# Altium schematic sheet lt_sys.SchDoc(lt_sys)
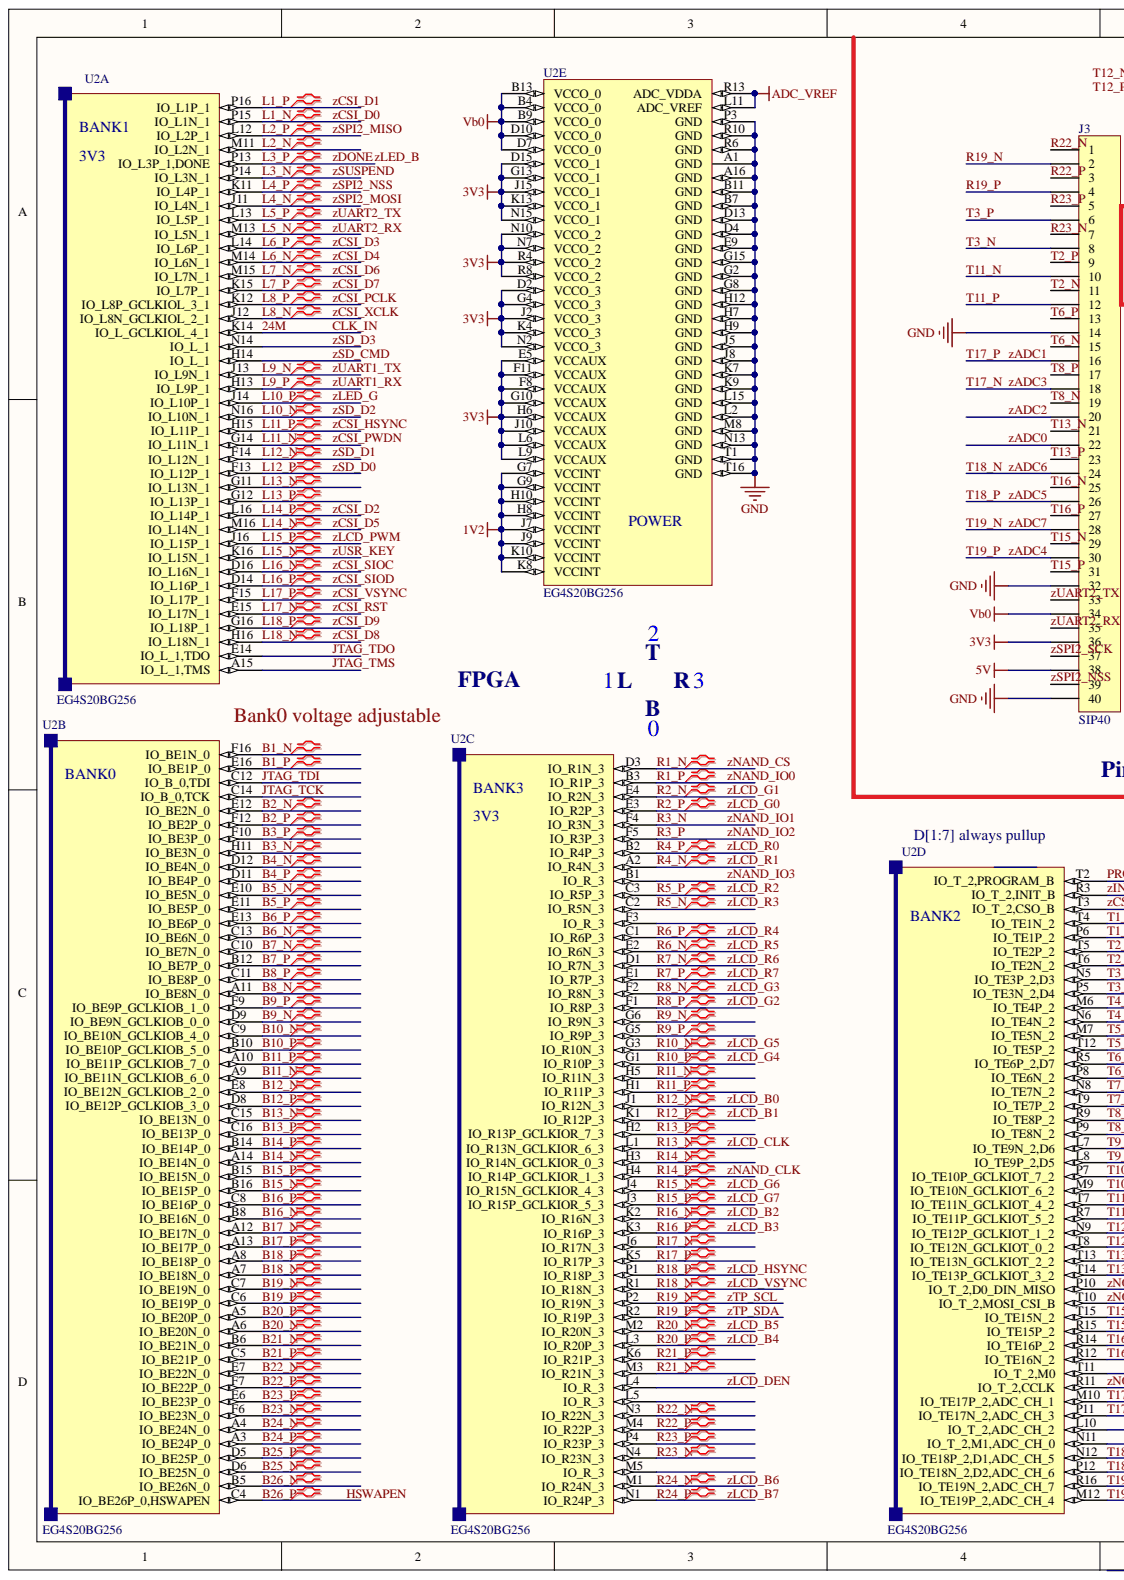
[diagram p0: lt_sys.SchDoc, left]
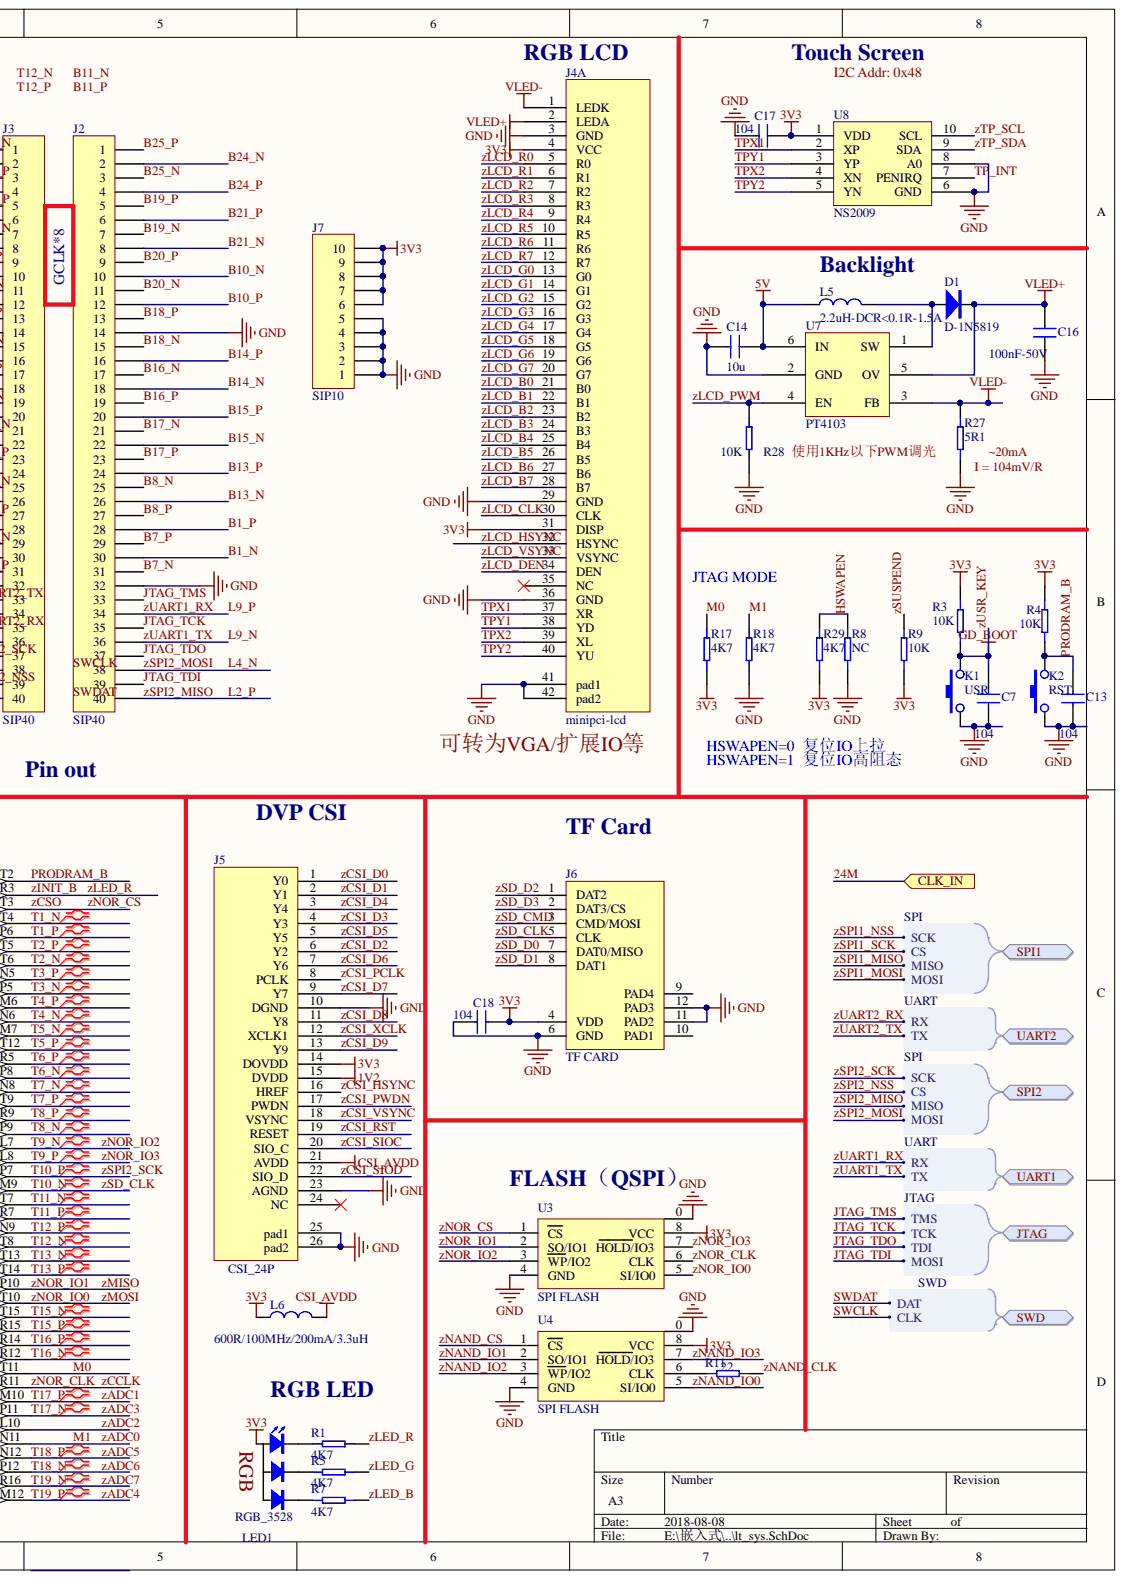
[diagram p1: lt_sys.SchDoc, right]

## Parts
C7  104  [0402C]
C13  104  [0402C]
C14  10u  [0603C]
C16  100nF-50V  [0402C]
C17  104  [0402C]
C18  104  [0402C]
D1  D-1N5819  [SOD-323]
J2  SIP40  [SIP40_20_1.27]
J3  SIP40  [SIP40_20_1.27]
J4  minipci-lcd  [FPC-40P-0.5]  (part A)
J5  CSI_24P  [FPC-24P-0.5]
J6  TF CARD  [TF_self-ejectC]
J7  SIP10  [SIP10_2.54_smt]
K1  USR  [key4_3x4]
K2  RST  [key4_3x4]
L5  2.2uH-DCR<0.1R-1.5A  [0806L]
L6  600R/100MHz/200mA/3.3uH  [0603R]
LED1  RGB_3528  [LED_RGB3528]
R1  4K7  [0402R]
R3  10K  [0402R]
R4  10K  [0402R]
R5  4K7  [0402R]
R7  4K7  [0402R]
R8  NC  [0402R]
R9  10K  [0402R]
R11  22  [0402R]  (value not drawn)
R17  4K7  [0402R]
R18  4K7  [0402R]
R27  5R1  [0402R]
R28  10K  [0402R]
R29  4K7  [0402R]
U2  EG4S20BG256  [FG256]  (parts A,B,C,D,E)
U3  SPI FLASH  [WSON8]
U4  SPI FLASH  [WSON8]
U7  PT4103  [sot23_6]
U8  NS2009  [MSOP10]

## Ports
CLK_IN, JTAG, JTAG.MOSI, JTAG.TCK, JTAG.TDI, JTAG.TMS, SPI1, SPI1.CS, SPI1.MISO, SPI1.MOSI, SPI1.SCK, SPI2, SPI2.CS, SPI2.MISO, SPI2.MOSI, SPI2.SCK, SWD, SWD.CLK, SWD.DAT, UART1, UART1.RX, UART1.TX, UART2, UART2.RX, UART2.TX

## Nets
1V2: J5.15(DVDD) U2.G7(VCCINT) U2.G9(VCCINT) U2.H8(VCCINT) U2.H10(VCCINT) U2.J7(VCCINT) U2.J9(VCCINT) U2.K8(VCCINT) U2.K10(VCCINT)
3V3: C17.1 C18.1 J3.36 J4.4(VCC) J4.31(DISP) J5.14(DOVDD) J6.4(VDD) J7.6 J7.7 J7.8 J7.9 J7.10 L6.2 LED1.2 R3.2 R4.2 R9.2 R17.1 R29.2 U2.D2(VCCO_3) U2.D15(VCCO_1) U2.E5(VCCAUX) U2.F8(VCCAUX) U2.F11(VCCAUX) U2.G4(VCCO_3) U2.G10(VCCAUX) U2.G13(VCCO_1) U2.H6(VCCAUX) U2.J2(VCCO_3) U2.J10(VCCAUX) U2.J15(VCCO_1) U2.K4(VCCO_3) U2.K13(VCCO_1) U2.L6(VCCAUX) U2.L9(VCCAUX) U2.N2(VCCO_3) U2.N7(VCCO_2) U2.N10(VCCO_2) U2.N15(VCCO_1) U2.R4(VCCO_2) U2.R8(VCCO_2) U3.8(VCC) U4.8(VCC) U8.1(VDD)
5V: C14.1 J3.38 L5.2 U7.6(IN)
ADC_VREF: U2.L11(ADC_VREF) U2.R13(ADC_VDDA)
CSI_AVDD: J5.21(AVDD) L6.1
GND: C7.2 C13.2 C14.2 C16.1 C17.2 C18.2 J2.14 J2.32 J3.14 J3.32 J3.40 J4.3(GND) J4.29(GND) J4.36(GND) J4.41(pad1) J4.42(pad2) J5.10(DGND) J5.23(AGND) J5.25(pad1) J5.26(pad2) J6.6(GND) J6.11(PAD2) J6.12(PAD3) J7.1 J7.2 J7.3 J7.4 J7.5 K1.1 K2.1 R8.2 R18.1 R27.1 R28.2 U2.A1(GND) U2.A16(GND) U2.B7(GND) U2.B11(GND) U2.D4(GND) U2.D13(GND) U2.E9(GND) U2.G2(GND) U2.G8(GND) U2.G15(GND) U2.H7(GND) U2.H9(GND) U2.H12(GND) U2.J5(GND) U2.J8(GND) U2.K7(GND) U2.K9(GND) U2.L2(GND) U2.L15(GND) U2.M8(GND) U2.N13(GND) U2.P3(GND) U2.R6(GND) U2.R10(GND) U2.T1(GND) U2.T16(GND) U3.0 U3.4(GND) U4.0 U4.4(GND) U7.2(GND) U8.6(GND) U8.8(A0)
VB0: J3.34 U2.B4(VCCO_0) U2.B9(VCCO_0) U2.B13(VCCO_0) U2.D7(VCCO_0) U2.D10(VCCO_0)
VLED+: C16.2 D1.K J4.2(LEDA) U7.5(OV)
VLED-: J4.1(LEDK) R27.2 U7.3(FB)
24M: U2.K14(IO_L_GCLKIOL_4_1) ; port CLK_IN
B1_N: J2.30 U2.F16(IO_BE1N_0)
B1_P: J2.28 U2.E16(IO_BE1P_0)
B2_N: U2.E12(IO_BE2N_0)
B2_P: U2.F12(IO_BE2P_0)
B3_N: U2.H11(IO_BE3N_0)
B3_P: U2.F10(IO_BE3P_0)
B4_N: U2.D12(IO_BE4N_0)
B4_P: U2.D11(IO_BE4P_0)
B5_N: U2.E10(IO_BE5N_0)
B5_P: U2.E11(IO_BE5P_0)
B6_N: U2.C13(IO_BE6N_0)
B6_P: U2.E13(IO_BE6P_0)
B7_N: J2.31 U2.C10(IO_BE7N_0)
B7_P: J2.29 U2.B12(IO_BE7P_0)
B8_N: J2.25 U2.A11(IO_BE8N_0)
B8_P: J2.27 U2.C11(IO_BE8P_0)
B9_N: U2.D9(IO_BE9N_GCLKIOB_0_0)
B9_P: U2.F9(IO_BE9P_GCLKIOB_1_0)
B10_N: J2.10 U2.C9(IO_BE10N_GCLKIOB_4_0)
B10_P: J2.12 U2.B10(IO_BE10P_GCLKIOB_5_0)
B11_N: U2.A9(IO_BE11N_GCLKIOB_6_0)
B11_P: U2.A10(IO_BE11P_GCLKIOB_7_0)
B12_N: U2.E8(IO_BE12N_GCLKIOB_2_0)
B12_P: U2.D8(IO_BE12P_GCLKIOB_3_0)
B13_N: J2.26 U2.C15(IO_BE13N_0)
B13_P: J2.24 U2.C16(IO_BE13P_0)
B14_N: J2.18 U2.A14(IO_BE14N_0)
B14_P: J2.16 U2.B14(IO_BE14P_0)
B15_N: J2.22 U2.B16(IO_BE15P_0)
B15_P: J2.20 U2.B15(IO_BE15N_0)
B16_N: J2.17 U2.B8(IO_BE16N_0)
B16_P: J2.19 U2.C8(IO_BE16P_0)
B17_N: J2.21 U2.A12(IO_BE17N_0)
B17_P: J2.23 U2.A13(IO_BE17P_0)
B18_N: J2.15 U2.A7(IO_BE18N_0)
B18_P: J2.13 U2.A8(IO_BE18P_0)
B19_N: J2.7 U2.C7(IO_BE19N_0)
B19_P: J2.5 U2.C6(IO_BE19P_0)
B20_N: J2.11 U2.A6(IO_BE20N_0)
B20_P: J2.9 U2.A5(IO_BE20P_0)
B21_N: J2.8 U2.B6(IO_BE21N_0)
B21_P: J2.6 U2.C5(IO_BE21P_0)
B22_N: U2.E7(IO_BE22N_0)
B22_P: U2.F7(IO_BE22P_0)
B23_N: U2.F6(IO_BE23N_0)
B23_P: U2.E6(IO_BE23P_0)
B24_N: J2.2 U2.A4(IO_BE24N_0)
B24_P: J2.4 U2.A3(IO_BE24P_0)
B25_N: J2.3 U2.D6(IO_BE25N_0)
B25_P: J2.1 U2.D5(IO_BE25P_0)
B26_N: U2.B5(IO_BE26N_0)
B26_P: R8.1 R29.1 U2.C4(IO_BE26P_0,HSWAPEN)
JTAG_TCK: J2.35 U2.C14(IO_B_0,TCK) ; port JTAG
JTAG_TDI: J2.39 U2.C12(IO_B_0,TDI) ; port JTAG
JTAG_TDO: J2.37 U2.E14(IO_L_1,TDO) ; port JTAG
JTAG_TMS: J2.33 U2.A15(IO_L_1,TMS) ; port JTAG
L1_N: J5.1(Y0) U2.P15(IO_L1N_1)
L1_P: J5.2(Y1) U2.P16(IO_L1P_1)
L2_N: U2.M11(IO_L2N_1)
L2_P: J2.40 U2.L12(IO_L2P_1) ; port SPI2
L3_N: R9.1 U2.P14(IO_L3N_1)
L3_P: R7.1 U2.P13(IO_L3P_1,DONE)
L4_N: J2.38 U2.J11(IO_L4N_1) ; port SPI2
L4_P: J3.39 U2.K11(IO_L4P_1) ; port SPI2
L5_N: J3.35 U2.M13(IO_L5N_1) ; port UART2
L5_P: J3.33 U2.L13(IO_L5P_1) ; port UART2
L6_N: J5.3(Y4) U2.M14(IO_L6N_1)
L6_P: J5.4(Y3) U2.L14(IO_L6P_1)
L7_N: J5.7(Y6) U2.M15(IO_L7N_1)
L7_P: J5.9(Y7) U2.K15(IO_L7P_1)
L8_N: J5.12(XCLK1) U2.J12(IO_L8N_GCLKIOL_2_1)
L8_P: J5.8(PCLK) U2.K12(IO_L8P_GCLKIOL_3_1)
L9_N: J2.36 U2.J13(IO_L9N_1) ; port UART1
L9_P: J2.34 U2.H13(IO_L9P_1) ; port UART1
L10_N: J6.1(DAT2) U2.N16(IO_L10N_1)
L10_P: R5.1 U2.J14(IO_L10P_1)
L11_N: J5.17(PWDN) U2.G14(IO_L11N_1)
L11_P: J5.16(HREF) U2.H15(IO_L11P_1)
L12_N: J6.8(DAT1) U2.F14(IO_L12N_1)
L12_P: J6.7(DAT0/MISO) U2.F13(IO_L12P_1)
L13_N: U2.G11(IO_L13N_1)
L13_P: U2.G12(IO_L13P_1)
L14_N: J5.5(Y5) U2.M16(IO_L14N_1)
L14_P: J5.6(Y2) U2.L16(IO_L14P_1)
L15_N: C7.1 K1.2 R3.1 U2.K16(IO_L15N_1)
L15_P: R28.1 U2.J16(IO_L15P_1) U7.4(EN)
L16_N: J5.20(SIO_C) U2.D16(IO_L16N_1)
L16_P: J5.22(SIO_D) U2.D14(IO_L16P_1)
L17_N: J5.19(RESET) U2.E15(IO_L17N_1)
L17_P: J5.18(VSYNC) U2.F15(IO_L17P_1)
L18_N: J5.11(Y8) U2.H16(IO_L18N_1)
L18_P: J5.13(Y9) U2.G16(IO_L18P_1)
M0: R17.2 U2.T11(IO_T_2,M0)
M1: J3.22 R18.2 U2.N11(IO_T_2,M1,ADC_CH_0)
PRODRAM_B: C13.1 K2.2 R4.1 U2.T2(IO_T_2,PROGRAM_B)
R1_N: U2.D3(IO_R1N_3) U4.1(CS)
R1_P: U2.B3(IO_R1P_3) U4.5(SI/IO0)
R2_N: J4.14(G1) U2.E4(IO_R2N_3)
R2_P: J4.13(G0) U2.E3(IO_R2P_3)
R3_N: U2.F4(IO_R3N_3) U4.2(SO/IO1)
R3_P: U2.F5(IO_R3P_3) U4.3(WP/IO2)
R4_N: J4.6(R1) U2.A2(IO_R4N_3)
R4_P: J4.5(R0) U2.B2(IO_R4P_3)
R5_N: J4.8(R3) U2.C2(IO_R5N_3)
R5_P: J4.7(R2) U2.C3(IO_R5P_3)
R6_N: J4.10(R5) U2.E2(IO_R6N_3)
R6_P: J4.9(R4) U2.C1(IO_R6P_3)
R7_N: J4.11(R6) U2.D1(IO_R7N_3)
R7_P: J4.12(R7) U2.E1(IO_R7P_3)
R8_N: J4.16(G3) U2.F2(IO_R8N_3)
R8_P: J4.15(G2) U2.F1(IO_R8P_3)
R9_N: U2.G6(IO_R9N_3)
R9_P: U2.G5(IO_R9P_3)
R10_N: J4.18(G5) U2.G3(IO_R10N_3)
R10_P: J4.17(G4) U2.G1(IO_R10P_3)
R11_N: U2.H5(IO_R11N_3)
R11_P: U2.H1(IO_R11P_3)
R12_N: J4.21(B0) U2.J1(IO_R12N_3)
R12_P: J4.22(B1) U2.K1(IO_R12P_3)
R13_N: J4.30(CLK) U2.L1(IO_R13N_GCLKIOR_6_3)
R13_P: U2.H2(IO_R13P_GCLKIOR_7_3)
R14_N: U2.H3(IO_R14N_GCLKIOR_0_3)
R14_P: R11.1 U2.H4(IO_R14P_GCLKIOR_1_3)
R15_N: J4.19(G6) U2.J4(IO_R15N_GCLKIOR_4_3)
R15_P: J4.20(G7) U2.J3(IO_R15P_GCLKIOR_5_3)
R16_N: J4.23(B2) U2.K2(IO_R16N_3)
R16_P: J4.24(B3) U2.K3(IO_R16P_3)
R17_N: U2.J6(IO_R17N_3)
R17_P: U2.K5(IO_R17P_3)
R18_N: J4.33(VSYNC) U2.R1(IO_R18N_3)
R18_P: J4.32(HSYNC) U2.P1(IO_R18P_3)
R19_N: J3.2 U2.P2(IO_R19N_3) U8.10(SCL)
R19_P: J3.4 U2.R2(IO_R19P_3) U8.9(SDA)
R20_N: J4.26(B5) U2.M2(IO_R20N_3)
R20_P: J4.25(B4) U2.L3(IO_R20P_3)
R21_N: U2.M3(IO_R21N_3)
R21_P: U2.K6(IO_R21P_3)
R22_N: J3.1 U2.N3(IO_R22N_3)
R22_P: J3.3 U2.M4(IO_R22P_3)
R23_N: J3.7 U2.N4(IO_R23N_3)
R23_P: J3.5 U2.P4(IO_R23P_3)
R24_N: J4.27(B6) U2.M1(IO_R24N_3)
R24_P: J4.28(B7) U2.N1(IO_R24P_3)
T1_N: U2.T4(IO_TE1N_2)
T1_P: U2.P6(IO_TE1P_2)
T2_N: J3.11 U2.T6(IO_TE2N_2)
T2_P: J3.9 U2.T5(IO_TE2P_2)
T3_N: J3.8 U2.P5(IO_TE3N_2,D4)
T3_P: J3.6 U2.N5(IO_TE3P_2,D3)
T4_N: U2.N6(IO_TE4N_2)
T4_P: U2.M6(IO_TE4P_2)
T5_N: U2.M7(IO_TE5N_2)
T5_P: U2.T12(IO_TE5P_2)
T6_N: J3.15 U2.P8(IO_TE6N_2)
T6_P: J3.13 U2.R5(IO_TE6P_2,D7)
T7_N: U2.N8(IO_TE7N_2)
T7_P: U2.T9(IO_TE7P_2)
T8_N: J3.19 U2.P9(IO_TE8N_2)
T8_P: J3.17 U2.R9(IO_TE8P_2)
T9_N: U2.L7(IO_TE9N_2,D6) U3.3(WP/IO2)
T9_P: U2.L8(IO_TE9P_2,D5) U3.7(HOLD/IO3)
T10_N: J6.5(CLK) U2.M9(IO_TE10N_GCLKIOT_6_2)
T10_P: J3.37 U2.P7(IO_TE10P_GCLKIOT_7_2) ; port SPI2
T11_N: J3.10 U2.T7(IO_TE11N_GCLKIOT_4_2)
T11_P: J3.12 U2.R7(IO_TE11P_GCLKIOT_5_2)
T12_N: U2.T8(IO_TE12N_GCLKIOT_0_2)
T12_P: U2.N9(IO_TE12P_GCLKIOT_1_2)
T13_N: J3.21 U2.T13(IO_TE13N_GCLKIOT_2_2)
T13_P: J3.23 U2.T14(IO_TE13P_GCLKIOT_3_2)
T15_N: J3.29 U2.T15(IO_TE15N_2)
T15_P: J3.31 U2.R15(IO_TE15P_2)
T16_N: J3.25 U2.R12(IO_TE16N_2)
T16_P: J3.27 U2.R14(IO_TE16P_2)
T17_N: J3.18 U2.P11(IO_TE17N_2,ADC_CH_3)
T17_P: J3.16 U2.M10(IO_TE17P_2,ADC_CH_1)
T18_N: J3.24 U2.P12(IO_TE18N_2,D2,ADC_CH_6)
T18_P: J3.26 U2.N12(IO_TE18P_2,D1,ADC_CH_5)
T19_N: J3.28 U2.R16(IO_TE19N_2,ADC_CH_7)
T19_P: J3.30 U2.M12(IO_TE19P_2,ADC_CH_4)
TP_INT: U8.7(PENIRQ)
TPX1: J4.37(XR) U8.2(XP)
TPX2: J4.39(XL) U8.4(XN)
TPY1: J4.38(YD) U8.3(YP)
TPY2: J4.40(YU) U8.5(YN)
ZADC2: J3.20 U2.L10(IO_T_2,ADC_CH_2)
ZCCLK: U2.R11(IO_T_2,CCLK) U3.6(CLK)
ZCSO: U2.T3(IO_T_2,CSO_B) U3.1(CS)
ZINIT_B: R1.1 U2.R3(IO_T_2,INIT_B)
ZLCD_DEN: J4.34(DEN) U2.L4(IO_R_3)
ZMISO: U2.P10(IO_T_2,D0_DIN_MISO) U3.2(SO/IO1)
ZMOSI: U2.T10(IO_T_2,MOSI_CSI_B) U3.5(SI/IO0)
ZNAND_IO3: U2.B1(IO_R_3) U4.7(HOLD/IO3)
ZSD_CMD: J6.3(CMD/MOSI) U2.H14(IO_L_1)
ZSD_D3: J6.2(DAT3/CS) U2.N14(IO_L_1)
NetD1_A: D1.A L5.1 U7.1(SW)
NetLED1_1: LED1.1 R1.2
NetLED1_3: LED1.3 R7.2
NetLED1_4: LED1.4 R5.2
NetR11_2: R11.2 U4.6(CLK)
N00144:
N00145:
SWCLK: ; port SWD
SWDAT: ; port SWD
ZSPI1_MISO: ; port SPI1
ZSPI1_MOSI: ; port SPI1
ZSPI1_NSS: ; port SPI1
ZSPI1_SCK: ; port SPI1

Not connected: J4 35(NC); J5 24(NC); J6 9(PAD4) 10(PAD1); U2 F3(IO_R_3) L5(IO_R_3) M5(IO_R_3)

## Library data of the parts
C7 C13 C14 C16-C18 = CAP: SMD-CAP; Component Kind: Standard; Library Name: RCDQLY.SCHLIB
D1 = D-1N5819: Diode; Component Kind: Standard; Library Name: RCDQLY.SCHLIB
J4 = minipci_lcd: Component Kind: Standard; Library Name: jointbar.SCHLIB
J6 = SD_Card_flip: Component Kind: Standard; Library Name: jointbar.SCHLIB
J7 = SIP10: jointbar; Component Kind: Standard; Library Name: jointbar.SCHLIB
K1 K2 = KEY: Component Kind: Standard; Library Name: rest.SCHLIB
L5 L6 = L1: 电感; Component Kind: Standard; Library Name: RCDQLY.SCHLIB
LED1 = LED_RGB3528: Component Kind: Standard; Library Name: RCDQLY.SCHLIB
R1 R3-R5 R7-R9 R11 R17 R18 R27-R29 = R: Component Kind: Standard; Library Name: RCDQLY.SCHLIB
U3 U4 = SPI_FLASH: Component Kind: Standard; Library Name: IC.SCHLIB
U7 = PT4104: Component Kind: Standard; Library Name: IC.SCHLIB
J2 J3 = SIP40: Component Kind: Standard; Library Name: jointbar.SCHLIB
J5 = CSI_24P: Component Kind: Standard; Library Name: jointbar.SCHLIB
U2 = EG4S20BG256: Component Kind: Standard; Library Name: IC.SCHLIB
U8 = NS2009: Component Kind: Standard; Library Name: IC.SCHLIB
